# Altium schematic sheet LSM6DSV80X-LIS2MDL-V1.0.SchDoc
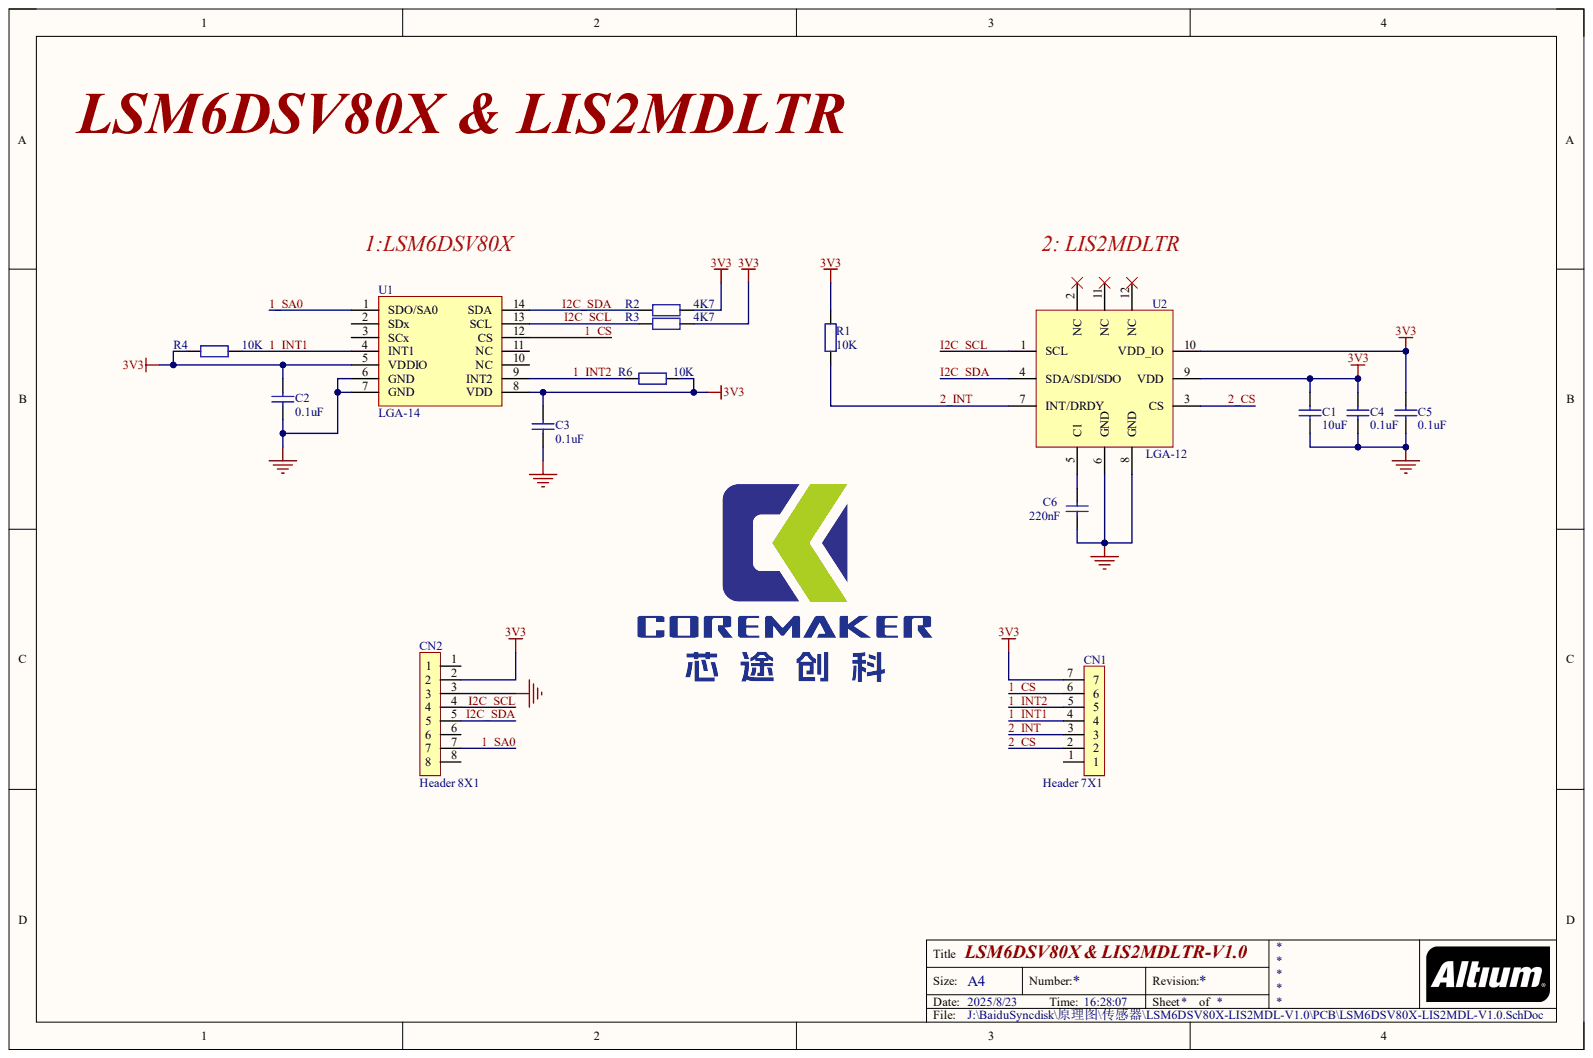
[diagram p0: LSM6DSV80X-LIS2MDL-V1.0.SchDoc]

## Parts
C1  10uF  [CAP_0603]
C2  0.1uF  [CAP_0603]
C3  0.1uF  [CAP_0603]
C4  0.1uF  [CAP_0603]
C5  0.1uF  [CAP_0603]
C6  220nF  [CAP_0603]
CN1  Header 7X1  [1X7PIN]
CN2  Header 8X1  [1X8PIN]
R1  10K  [RES_0603]
R2  4K7  [RES_0603]
R3  4K7  [RES_0603]
R4  10K  [RES_0603]
R6  10K  [RES_0603]
U1  LGA-14  [LGA-14]
U2  LGA-12  [LGA-12]

## Nets
3V3: C1.2 C2.1 C3.1 C4.2 C5.2 CN1.7 CN2.2 R1.1 R2.1 R3.1 R4.2 R6.1 U1.5 U1.8 U2.9 U2.10
GND: C1.1 C2.2 C3.2 C4.1 C5.1 C6.2 CN2.3 U1.6 U1.7 U2.6 U2.8
1_CS: CN1.6 U1.12
1_INT1: CN1.4 R4.1 U1.4
1_INT2: CN1.5 R6.2 U1.9
1_SA0: CN2.7 U1.1
2_CS: CN1.2 U2.3
2_INT: CN1.3 R1.2 U2.7
I2C_SCL: CN2.4 R3.2 U1.13 U2.1
I2C_SDA: CN2.5 R2.2 U1.14 U2.4
NetC6_1: C6.1 U2.5

Not connected: CN1 1; CN2 1 6 8; U1 2 3 10 11; U2 2 11 12

## Library data of the parts
C1-C6 = CAP: Component Kind: Standard; Description: CAP; Library Name: ST_2.4G.SchLib
R1-R4 R6 = Res: Component Kind: Standard; Description: Res; Library Name: ST_2.4G.SchLib
CN1 = Header 7X1: Component Kind: Standard; Description: Header 7X1; Library Name: LIS3MDLTR_PCB.SchLib
CN2 = Header 8X1: Component Kind: Standard; Description: Header 8X1; Library Name: 1019_WB55_Senser.SchLib
U1 = LGA-14: Component Kind: Standard; Description: LGA-14; Library Name: LSM6DS3TR-C_LGA-14.SchLib
U2 = LGA-12: Component Kind: Standard; Description: LGA-12; Library Name: LIS2MDLTR_PCB.SchLib
